# Altium schematic sheet interface2.SchDoc
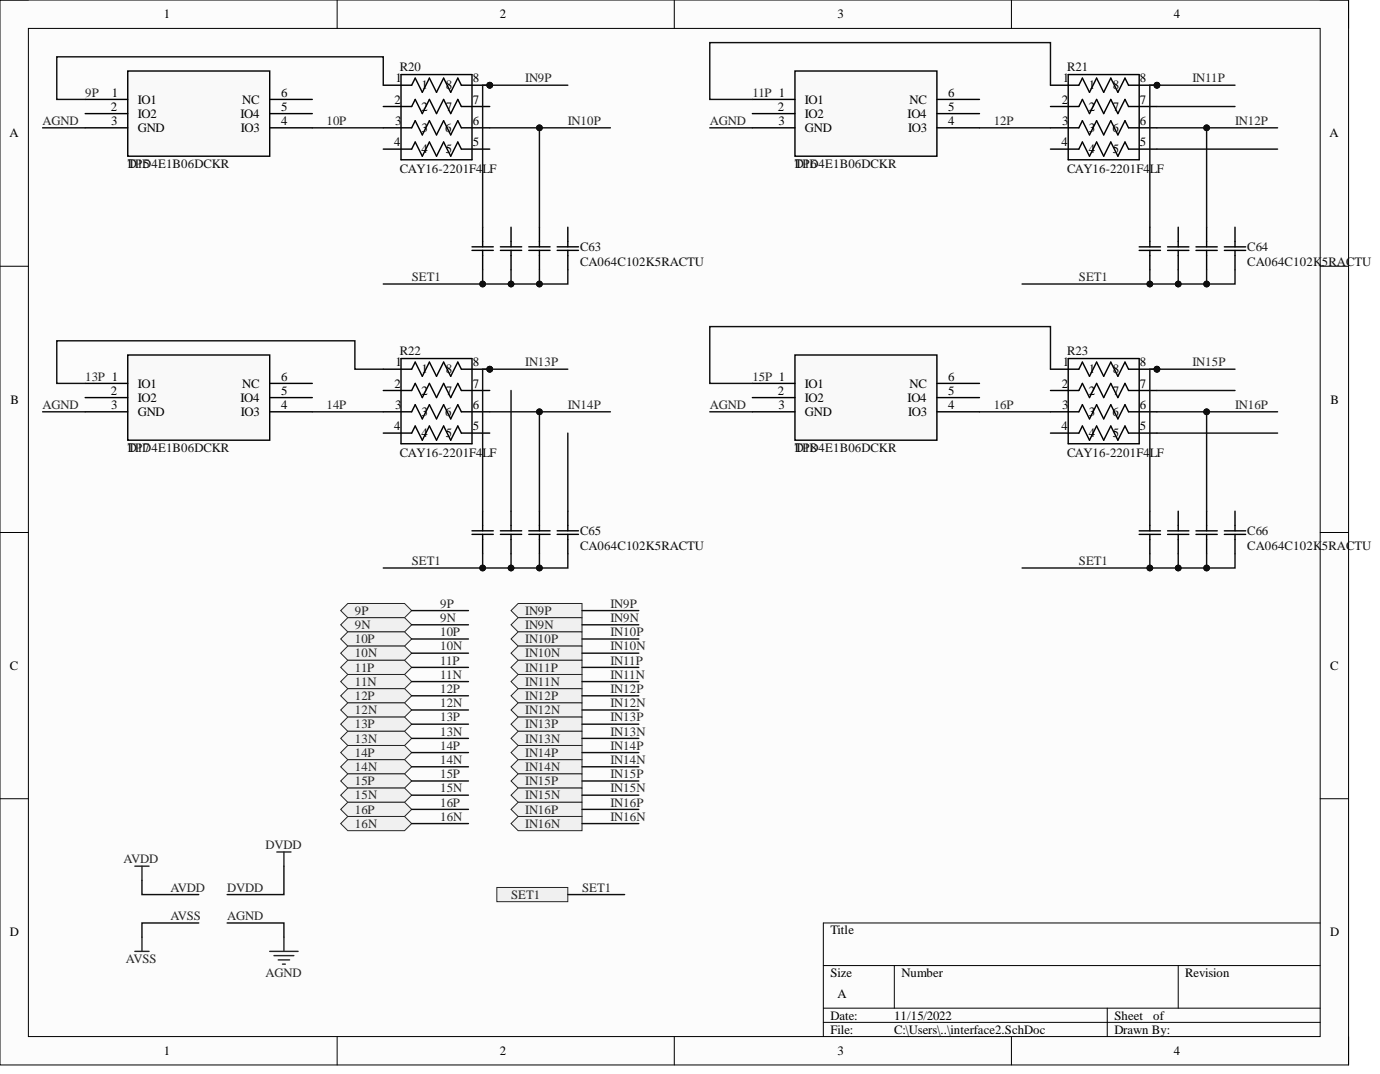
[diagram p0: interface2.SchDoc]

## Parts
C63  CA064C102K5RACTU  [CA064]
C64  CA064C102K5RACTU  [CA064]
C65  CA064C102K5RACTU  [CA064]
C66  CA064C102K5RACTU  [CA064]
D15  TPD4E1B06DCKR  [DCK6]
D16  TPD4E1B06DCKR  [DCK6]
D17  TPD4E1B06DCKR  [DCK6]
D18  TPD4E1B06DCKR  [DCK6]
R20  CAY16-2201F4LF  [*RESCAXE80P320X160-8N]
R21  CAY16-2201F4LF  [*RESCAXE80P320X160-8N]
R22  CAY16-2201F4LF  [*RESCAXE80P320X160-8N]
R23  CAY16-2201F4LF  [*RESCAXE80P320X160-8N]

## Ports
9N, 9P, 10N, 10P, 11N, 11P, 12N, 12P, 13N, 13P, 14N, 14P, 15N, 15P, 16N, 16P, IN9N, IN9P, IN10N, IN10P, IN11N, IN11P, IN12N, IN12P, IN13N, IN13P, IN14N, IN14P, IN15N, IN15P, IN16N, IN16P, SET1

## Nets
9P: D15.1(IO1) R20.1 ; port 9P
10P: D15.4(IO3) R20.3 ; port 10P
11P: D16.1(IO1) R21.1 ; port 11P
12P: D16.4(IO3) R21.3 ; port 12P
13P: D17.1(IO1) R22.1 ; port 13P
14P: D17.4(IO3) R22.3 ; port 14P
15P: D18.1(IO1) R23.1 ; port 15P
16P: D18.4(IO3) R23.3 ; port 16P
AGND: D15.3(GND) D16.3(GND) D17.3(GND) D18.3(GND)
IN9P: C63.8 R20.8 ; port IN9P
IN10P: C63.6 R20.6 ; port IN10P
IN11P: C64.8 R21.8 ; port IN11P
IN12P: C64.6 R21.6 ; port IN12P
IN13P: C65.8 R22.8 ; port IN13P
IN14P: C65.6 R22.6 ; port IN14P
IN15P: C66.8 R23.8 ; port IN15P
IN16P: C66.6 R23.6 ; port IN16P
SET1: C63.1 C63.2 C63.3 C63.4 C64.1 C64.2 C64.3 C64.4 C65.1 C65.2 C65.3 C65.4 C66.1 C66.2 C66.3 C66.4 ; port SET1
9N: ; port 9N
10N: ; port 10N
11N: ; port 11N
12N: ; port 12N
13N: ; port 13N
14N: ; port 14N
15N: ; port 15N
16N: ; port 16N
AVDD:
AVSS:
DVDD:
IN9N: ; port IN9N
IN10N: ; port IN10N
IN11N: ; port IN11N
IN12N: ; port IN12N
IN13N: ; port IN13N
IN14N: ; port IN14N
IN15N: ; port IN15N
IN16N: ; port IN16N

Not connected: C63 5 7; C64 5 7; C65 5 7; C66 5 7; D15 2(IO2) 5(IO4) 6(NC); D16 2(IO2) 5(IO4) 6(NC); D17 2(IO2) 5(IO4) 6(NC); D18 2(IO2) 5(IO4) 6(NC); R20 2 4 5 7; R21 2 4 5 7; R22 2 4 5 7; R23 2 4 5 7

## Library data of the parts
C63-C66 = CA064C102K5RACTU: CAP ARRAY 1000PF 50V X7R 0612; Manufacturer 1: KEMET; Capacitance: 1nF; Circuit Type: Isolated; Dielectric Material: Ceramic; Number of Capacitors: 4; Tolerance: 10%; Case Code (Imperial): 0612; Case Code (Metric): 1632; Case/Package: 0612; Component Kind: Standard; ComponentLink1Description: http://www.farnell.com/datasheets/1868478.pdf?src-supplier=Farnell; ComponentLink1URL: http://www.farnell.com/datasheets/1868478.pdf?src-supplier=Farnell; ComponentLink2Description: https://media.digikey.com/pdf/Data%20Sheets/Kemet%20PDFs/X7R_Dielectric_10-200V_Rev_Nov2013.pdf?src-supplier=Digi-Key; ComponentLink2URL: https://media.digikey.com/pdf/Data%20Sheets/Kemet%20PDFs/X7R_Dielectric_10-200V_Rev_Nov2013.pdf?src-supplier=Digi-Key; ComponentLink3Description: http://www.mouser.com/ds/2/212/KEM_C1017_X7R_ARRAY_SMD-1102778.pdf?src-supplier=Mouser; ComponentLink3URL: http://www.mouser.com/ds/2/212/KEM_C1017_X7R_ARRAY_SMD-1102778.pdf?src-supplier=Mouser; ComponentLink4Description: http://www.farnell.com/datasheets/1868478.pdf?src-supplier=Element14; ComponentLink4URL: http://www.farnell.com/datasheets/1868478.pdf?src-supplier=Element14; ComponentLink5Description: http://capacitoredge.kemet.com/capedge2/DataSheet/Datasheet-CA064C102K5RACTU.pdf?pn=CA064C102K5RACTU&src-supplier=Avnet; ComponentLink5URL: http://capacitoredge.kemet.com/capedge2/DataSheet/Datasheet-CA064C102K5RACTU.pdf?pn=CA064C102K5RACTU&src-supplier=Avnet; ComponentLink6Description: http://capacitoredge.kemet.com/capedge2/DataSheet/Datasheet-CA064C102K5RACTU.pdf?pn=CA064C102K5RACTU&src-supplier=Avnet+Asia; ComponentLink6URL: http://capacitoredge.kemet.com/capedge2/DataSheet/Datasheet-CA064C102K5RACTU.pdf?pn=CA064C102K5RACTU&src-supplier=Avnet+Asia; Contact Plating: Tin,Matte; Dielectric: X7R; Height: 0.8mm; Height - Seated (Max): 0.035inch; Lead Free: Lead Free; Length: 0.063inch; Manufacturer Part Number 1: CA064C102K5RACTU; Max Operating Temperature: 125°C; Min Operating Temperature: -55°C; Mount: Surface Mount; Number of Circuits: 4; Number of Elements: 4; Number of Terminals: 8; Package Quantity: 4000; Packaging: Tape and Reel; Pricing 1: 1=0.61000, 10=0.42800, 100=0.28180, 500=0.20874, 1000=0.17743 (USD); REACH SVHC: No SVHC; RoHS: Yes; Stock 1: 12; Supplier 1: Digi-Key; Supplier Part Number 1: 399-9415-1-ND; Terminal Pitch: 0.8mm; Termination: SMD/SMT; Voltage Rating: 50V; Voltage Rating (DC): 50V; Width: 1.6mm; Library Name: EE_smallOB_BW_v1.0.SchLib
D15-D18 = TPD4E1B06DCKR: TVS DIODE 5.5VWM 14.5VC SC70-6; Manufacturer 1: Texas Instruments; Breakdown Voltage: 7V; Capacitance: 1pF; Clamping Voltage: 14.5V; Direction: Bidirectional,Unidirectional; ESD Protection: Yes; Interface: LVDS,USB; Max Power Dissipation: 45W; Max Reverse Leakage Current: 500pA; Number of Bidirectional Channels: 4; Operating Supply Voltage: 5.5V; Peak Pulse Current: 3A; Polarity: Bidirectional; Power Line Protection: No; Test Current: 1mA; Case/Package: SC; Component Kind: Standard; ComponentLink1Description: https://datasheet.ciiva.com/12545/tpd4e1b06-224687-12545147.pdf?src-supplier=Mouser; ComponentLink1URL: https://datasheet.ciiva.com/12545/tpd4e1b06-224687-12545147.pdf?src-supplier=Mouser; ComponentLink2Description: https://datasheet.ciiva.com/6574/tpd4e1b06-6574671.pdf?src-supplier=Digi-Key; ComponentLink2URL: https://datasheet.ciiva.com/6574/tpd4e1b06-6574671.pdf?src-supplier=Digi-Key; Height: 1mm; Lead Free: Lead Free; Length: 2.15mm; Manufacturer Part Number 1: TPD4E1B06DCKR; Max Operating Temperature: 125°C; Min Breakdown Voltage: 7V; Min Operating Temperature: -40°C; Mount: Surface Mount; Number of Channels: 4; Number of Pins: 6; Package Quantity: 3000; Packaging: Tape and Reel; Peak Pulse Power: 45W; Pricing 1: 1=0.58000, 10=0.49400, 100=0.36920, 500=0.29004, 1000=0.22413 (USD); REACH SVHC: No SVHC; Reverse Standoff Voltage: 5.5V; RoHS: Yes; Stock 1: 27694; Supplier 1: Digi-Key; Supplier Part Number 1: 296-39346-1-ND; Termination: SMD/SMT; Width: 1.4mm; Working Voltage: 5.5V; Library Name: EE_smallOB_BW_v1.0.SchLib
R20-R23 = CAY16-2201F4LF: BOURNS         CAY16-2201F4LF             RESISTOR, ARRAY-4, 2.2KOHM, 5%, SMD; Circuit Type: Isolated; Number of Circuits: 4; Power Per Element: 62.5mW; Power Rating: 248mW; Resistance: 2.2kR; Temperature Coefficient: 200ppm/°C; Tolerance: 1%; Voltage Rating: 50V; Case Code (Imperial): 1206; Case Code (Metric): 3216; Case/Package: 1206; Component Kind: Standard; ComponentLink1Description: https://datasheet.ciiva.com/11807/0900766b8064e462-11807027.pdf?src-supplier=RSComponents; ComponentLink1URL: https://datasheet.ciiva.com/11807/0900766b8064e462-11807027.pdf?src-supplier=RSComponents; ComponentLink2Description: https://datasheet.ciiva.com/16967/catcay-16967355.pdf?src-supplier=Avnet+Europe; ComponentLink2URL: https://datasheet.ciiva.com/16967/catcay-16967355.pdf?src-supplier=Avnet+Europe; ComponentLink3Description: https://datasheet.ciiva.com/6533/catcay-6533677.pdf?src-supplier=Digi-Key; ComponentLink3URL: https://datasheet.ciiva.com/6533/catcay-6533677.pdf?src-supplier=Digi-Key; ComponentLink4Description: https://datasheet.ciiva.com/30021/catcay-30021357.pdf?src-supplier=Avnet; ComponentLink4URL: https://datasheet.ciiva.com/30021/catcay-30021357.pdf?src-supplier=Avnet; ComponentLink5Description: https://datasheet.ciiva.com/1478/1849489-1478846.pdf?src-supplier=Element14; ComponentLink5URL: https://datasheet.ciiva.com/1478/1849489-1478846.pdf?src-supplier=Element14; ComponentLink6Description: https://datasheet.ciiva.com/6533/catcay-6533678.pdf?src-supplier=Digi-Key; ComponentLink6URL: https://datasheet.ciiva.com/6533/catcay-6533678.pdf?src-supplier=Digi-Key; ComponentLink7Description: https://datasheet.ciiva.com/1478/1849489-1478847.pdf?src-supplier=Element14; ComponentLink7URL: https://datasheet.ciiva.com/1478/1849489-1478847.pdf?src-supplier=Element14; ComponentLink8Description: https://datasheet.ciiva.com/6533/catcay-6533679.pdf?src-supplier=Digi-Key; ComponentLink8URL: https://datasheet.ciiva.com/6533/catcay-6533679.pdf?src-supplier=Digi-Key; ComponentLink9Description: https://datasheet.ciiva.com/48692/001055385-da-01-en-wid-arr-cay16-2201f4lf-2-2k-ohm-4-w-1206-48692149.pdf?src-supplier=Conrad; ComponentLink9URL: https://datasheet.ciiva.com/48692/001055385-da-01-en-wid-arr-cay16-2201f4lf-2-2k-ohm-4-w-1206-48692149.pdf?src-supplier=Conrad; Contact Plating: Tin; Depth: 1.6mm; Height: 0.024inch; Lead Free: Lead Free; Lead Pitch: 0.8mm; Length: 3.2mm; Manufacturer 1: Bourns; Manufacturer Part Number 1: CAY16-2201F4LF; Max Operating Temperature: 125°C; Military Standard: Not; Min Operating Temperature: -55°C; Mount: Surface Mount; Number of Elements: 4; Number of Pins: 8; Number of Resistors: 4; Number of Terminals: 8; Package Quantity: 1; Packaging: Tape and Reel; Pricing 1: 1=0.14000, 10=0.12100, 25=0.08800, 50=0.06380, 100=0.04730, 250=0.03632, 500=0.02860, 1000=0.01980 (USD); REACH SVHC: No SVHC; RoHS: Yes; Stock 1: 35310; Supplier 1: Digi-Key; Supplier Part Number 1: CAY16-2201F4LFCT-ND; Terminal Pitch: 0.8mm; Termination: Convex; Voltage Rating (DC): 50V; Width: 0.063inch; Library Name: EE_smallOB_BW_v1.0.SchLib
